# Altium schematic sheet Misc.SchDoc(Misc)
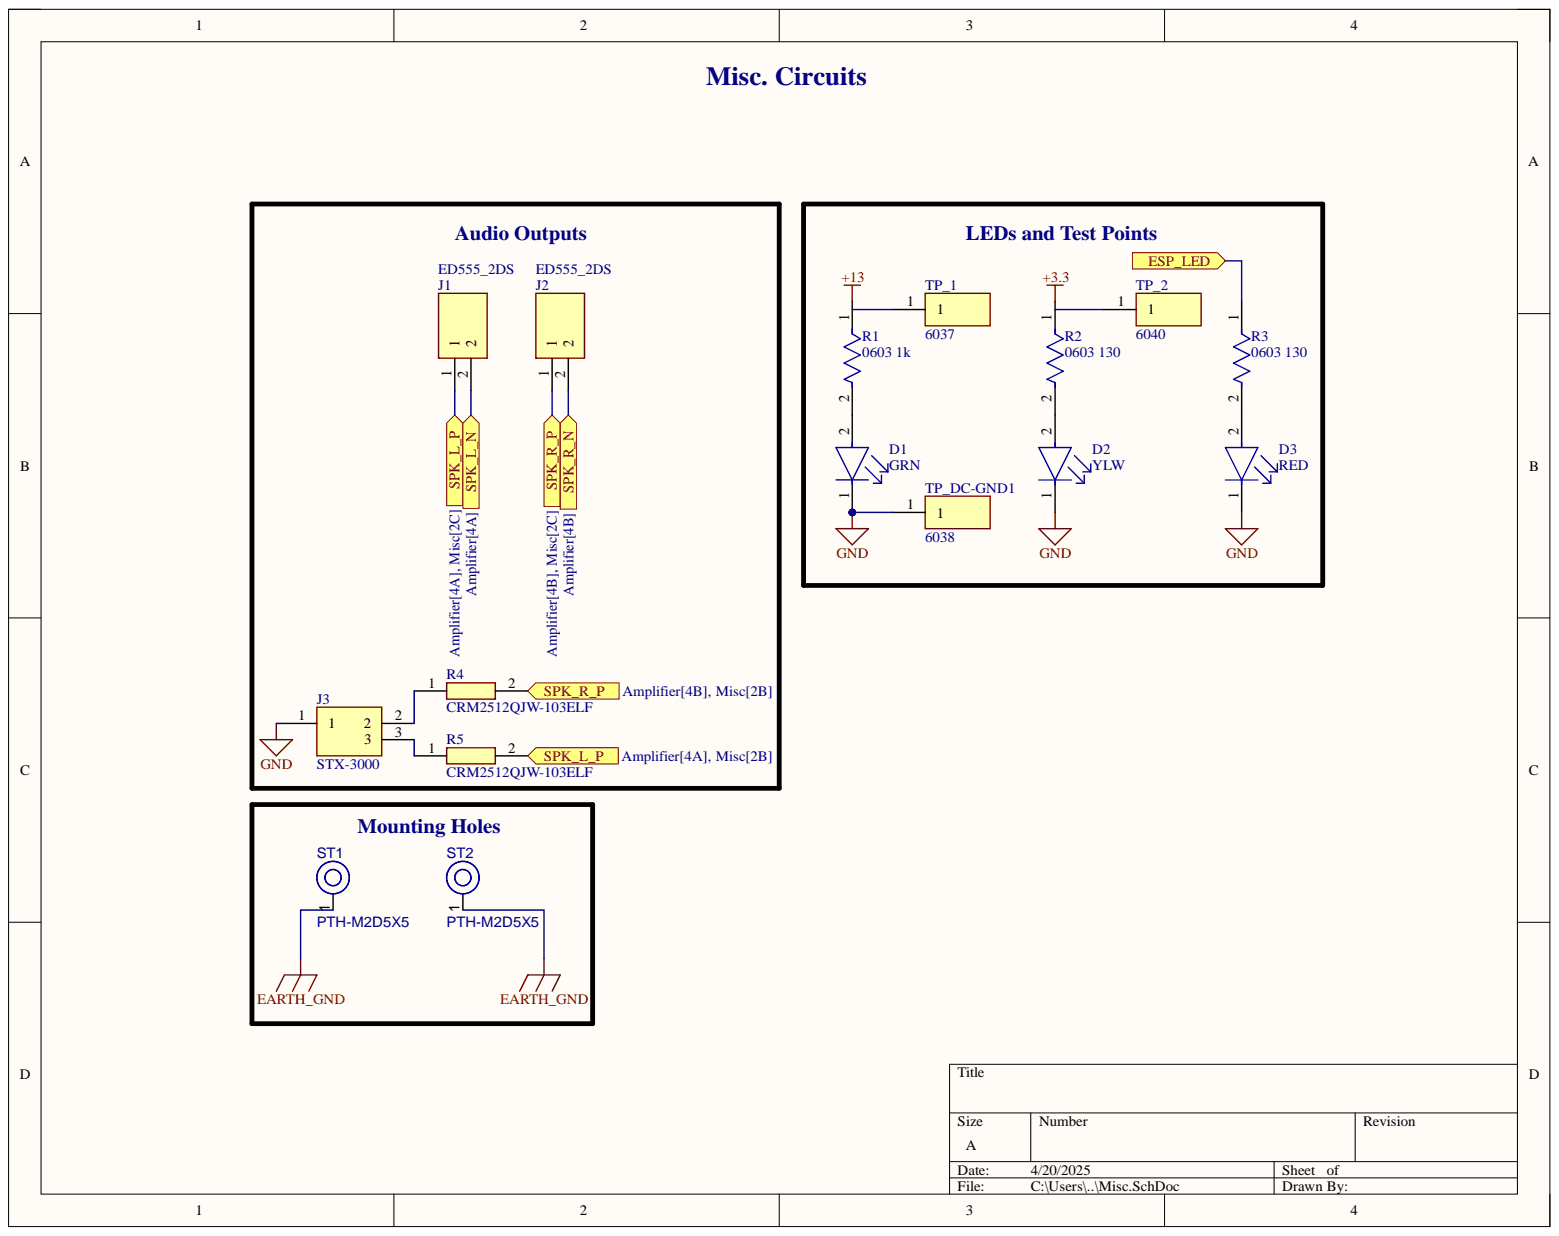
[diagram p0: Misc.SchDoc]

## Parts
D1  GRN  [FP-CMD15-21-MFG]
D2  YLW  [FP-CMD15-21-MFG]
D3  RED  [FP-CMD15-21-MFG]
J1  ED555_2DS  [SHDR2W90P0X350_1X2_700X650X820P]
J2  ED555_2DS  [SHDR2W90P0X350_1X2_700X650X820P]
J3  STX-3000  [STX3000]
R1  0603 1k  Case Code (Imperial): 0603  [FP-CRCW0603-e3-MFG]
R2  0603 130  Case Code (Imperial): 0603  [FP-CRCW0603-e3-MFG]
R3  0603 130  Case Code (Imperial): 0603  [FP-CRCW0603-e3-MFG]
R4  CRM2512QJW-103ELF  [RESC6331X80N]
R5  CRM2512QJW-103ELF  [RESC6331X80N]
ST1  PTH-M2D5X5  [PTH-M2D5X5]
ST2  PTH-M2D5X5  [PTH-M2D5X5]
TP_1  6037  [6037]
TP_2  6040  [6037]
TP_DC-GND1  6038  [6037]

## Ports
ESP_LED, SPK_L_N, SPK_L_P, SPK_R_N, SPK_R_P

## Nets
+3.3: R2.1
+13: R1.1
EARTH_GND: ST1.1 ST2.1
GND: D1.1 D2.1 D3.1 J3.1 TP_DC-GND1.1
ESP_LED: R3.1 ; port ESP_LED
SPK_L_N: J1.2 ; port SPK_L_N
SPK_L_P: J1.1 R5.2 ; port SPK_L_P
SPK_R_N: J2.2 ; port SPK_R_N
SPK_R_P: J2.1 R4.2 ; port SPK_R_P
NetD1_2: D1.2 R1.2
NetD2_2: D2.2 R2.2
NetD3_2: D3.2 R3.2
NetJ3_2: J3.2 R4.1
NetJ3_3: J3.3 R5.1

Not connected: TP_1 1; TP_2 1

## Library data of the parts
D1 D3 = CMP-53976-000002-1: LED RED DIFFUSED 1206 SMD; Manufacturer: VCC; Manufacturer Part Number: CMD15-21VRD/TR8; Forward Current: 20mA; Forward Voltage: 2V; Led Orientation: Top View; Luminous Intensity: 4mcd; Peak Emmision Wavelength: 640nm; Power Dissipation: 105mW; Reverse Voltage: 5V; Automotive: No; Category: Diode; Colour: Red; Component Kind: Standard; ComponentLink2Description: Datasheet; ComponentLink2URL: https://www.vcclite.com/wp-content/uploads/wpallimport/files/files/CMD1521SeriesRev1.pdf; Device Class L1: Optoelectronics; Device Class L2: LEDs; Device Class L3: unset; Digikey Description: LED RED DIFFUSED 1206 SMD; Height: 1.3mm; Lead Free: Yes; Library Name: Altium Content Vault; Mouser Description: Standard LEDs - SMD RED DIFFUSED; Octopart: https://octopart.com/cmd15-21vrd%2Ftr8-vcc-26668835; Package: CMD15-21; RoHS: TRUE; Temperature: -40°C to +85°C; Temperature Range High: +85°C; Temperature Range Low: -40°C
D2 = CMP-53976-000002-1: LED YELLOW DIFFUSED 1206 SMD; Manufacturer: VCC; Manufacturer Part Number: CMD15-21VRD/TR8; Colour: Red; Forward Current: 20mA; Forward Voltage: 2V; Led Orientation: Top View; Luminous Intensity: 4mcd; Peak Emmision Wavelength: 640nm; Power Dissipation: 105mW; Reverse Voltage: 5V; Automotive: No; Category: Diode; Component Kind: Standard; ComponentLink2Description: Datasheet; ComponentLink2URL: https://www.vcclite.com/wp-content/uploads/wpallimport/files/files/CMD1521SeriesRev1.pdf; Device Class L1: Optoelectronics; Device Class L2: LEDs; Device Class L3: unset; Digikey Description: LED RED DIFFUSED 1206 SMD; Height: 1.3mm; Lead Free: Yes; Library Name: Altium Content Vault; Mouser Description: Standard LEDs - SMD RED DIFFUSED; Octopart: https://octopart.com/cmd15-21vrd%2Ftr8-vcc-26668835; Package: CMD15-21; RoHS: TRUE; Temperature: -40°C to +85°C; Temperature Range High: +85°C; Temperature Range Low: -40°C
J1 J2 = ED555_2DS: Connector; Manufacturer_Name: On Shore Technology Inc.; Manufacturer_Part_Number: ED555/2DS; Arrow Part Number: ED555/2DS; Arrow Price/Stock: https://www.arrow.com/en/products/ed5552ds/on-shore-technology?utm_currency=USD&region=nac; Component Kind: Standard; Datasheet Link: http://www.on-shore.com/wp-content/uploads/ED555XDS.pdf; Height: 8.2mm
J3 = STX-3000: Connector; Manufacturer_Name: Kycon; Component Kind: Standard; Datasheet Link: http://www.kycon.com/Pub_Eng_Draw/STX-3000.pdf; Manufacturer_Part_Number: STX-3000; Mouser Part Number: 806-STX-3000; Mouser Price/Stock: https://www.mouser.co.uk/ProductDetail/Kycon/STX-3000?qs=kjZ2mQLP346Nbz1X9BOzfg%3D%3D
R1 = CMP-1666-00003-5: RES Thick Film, 1kΩ, 1%, 0.1W, 100ppm/°C, 0603; Max Power Dissipation: 100mW; Resistance: 1kR; Voltage Rating: 75V; Case Code (Metric): 1608; Case/Package: 0603; Component Kind: Standard; Composition: Thick Film; Contact Plating: Tin; Depth: 0.85mm; Features: Automotive AEC-Q200; Height: 0.45mm; Lead Free: Lead Free; Length: 1.55mm; Library Name: Altium Content Vault; Max Operating Temperature: 155°C; Military Standard: Not; Min Operating Temperature: -55°C; Mount: Surface Mount; Number of Pins: 2; Number of Terminations: 2; Package Quantity: 10; Packaging: Tape and Reel; Power Rating: 100mW; Radiation Hardening: No; Temperature Coefficient: 100ppm/°C; Termination: SMD/SMT; Tolerance: 1%; Voltage Rating (DC): 75V; Weight: 7.1E-05oz; Width: 0.033inch
R2 R3 = CMP-2000-03889-2: RES Thick Film, 130Ω, 1%, 0.1W, 100ppm/°C, 0603; Max Power Dissipation: 100mW; Resistance: 130R; Voltage Rating: 75V; Case Code (Metric): 1608; Case/Package: 0603; Component Kind: Standard; Composition: Thick Film; Contact Plating: Tin; Depth: 0.85mm; Features: Automotive AEC-Q200; Height: 0.45mm; Lead Free: Lead Free; Length: 1.55mm; Library Name: Altium Content Vault; Max Operating Temperature: 155°C; Military Standard: Not; Min Operating Temperature: -55°C; Number of Pins: 2; Number of Terminations: 2; Package Quantity: 10; Packaging: Tape and Reel; Power Rating: 100mW; Radiation Hardening: No; Temperature Coefficient: 100ppm/°C; Termination: SMD/SMT; Tolerance: 1%; Voltage Rating (DC): 75V; Weight: 7.1E-05oz; Width: 0.85mm; Working Voltage: 75V
R4 R5 = CRM2512QJW-103ELF: Resistor; Manufacturer_Name: Bourns; Arrow Part Number: CRM2512QJW-103ELF; Arrow Price/Stock: https://www.arrow.com/en/products/crm2512qjw-103elf/bourns?region=nac; Component Kind: Standard; Datasheet Link: http://www.bourns.com/data/global/pdfs/CRM0805_1206_2010.pdf; Height: 0.8mm; Manufacturer_Part_Number: CRM2512QJW-103ELF; Mouser Part Number: 652-CRM2512QJW-103E; Mouser Price/Stock: https://www.mouser.co.uk/ProductDetail/Bourns/CRM2512QJW-103ELF?qs=8Wlm6%252BaMh8Rzg3bRHdWGsA%3D%3D
ST1 ST2 = CMP-00028-00004-2: M2 PTH (2.5mm), 5mm pad; Component Kind: Standard; Library Name: Spartan Racing Altium Workspace; Pins: 1
TP_1 TP_2 TP_DC-GND1 = 6037: Test Point; Manufacturer_Name: Keystone Electronics; Component Kind: Standard; Datasheet Link: https://www.keyelco.com/product-pdf.cfm?p=3184; Height: 8.22mm; Mouser Part Number: 534-6037; Mouser Price/Stock: https://www.mouser.co.uk/ProductDetail/Keystone-Electronics/6037?qs=RfgnRl00reQ%2FAdfdXZ%2FHVQ%3D%3D
  TP_1 TP_DC-GND1: Manufacturer_Part_Number: 6037
  TP_2: Manufacturer_Part_Number: 6040
